# Altium schematic sheet Sheet1.SchDoc
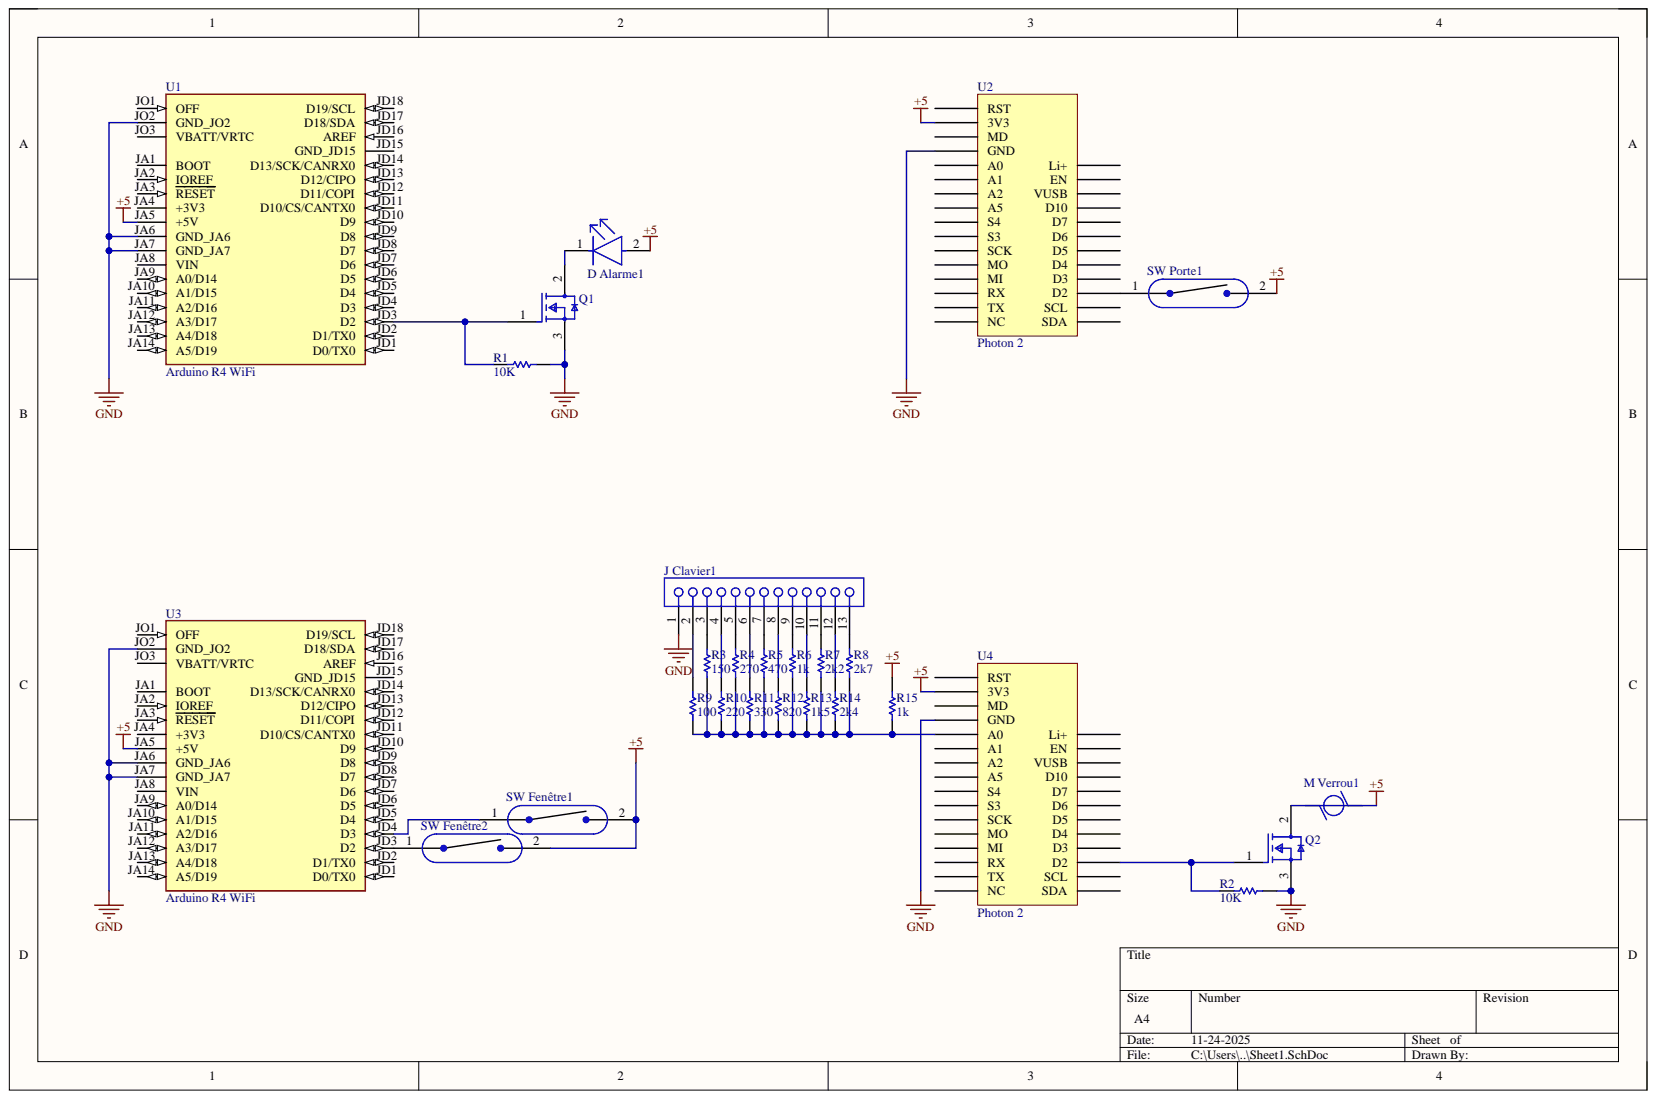
[diagram p0: Sheet1.SchDoc]

## Parts
D_Alarme1  HLMP-1301  [FP-HLMP-1301-MFG]  (value not drawn)
J_Clavier1  52808-1370  [MOLX-52808-1370]  (value not drawn)
M_Verrou1  MUMA042P1S  [FP-MUMA042P1S-MFG]  (value not drawn)
Q1  RJK6035DPP-A0#T2  [SIP450W69P254L1000H1755Q3_PRSS0003AP-A_N]  (value not drawn)
Q2  RJK6035DPP-A0#T2  [SIP450W69P254L1000H1755Q3_PRSS0003AP-A_N]  (value not drawn)
R1  Resistance: 10K  [RESC1609X55X30NL10T20]  (hidden comment: TNPW060310K0BEEN)
R2  Resistance: 10K  [RESC1609X55X30NL10T20]  (hidden comment: TNPW060310K0BEEN)
R3  CPF0201D150RE1  [RESC0603X28X15ML05T05]  (value not drawn)
R4  ERJ2RKF2700X  [RESC1005X40X25NL05T05]  (value not drawn)
R5  CRCW1210470RJNEAHP  [RESC3225X60X45NL20T20]  (value not drawn)
R6  Resistance: 1k  [RESC1005X35X25ML10T10]  (hidden comment: RT0402DRE071KL)
R7  Resistance: 2k2  [RESC0805(2012)_N]  (hidden comment: 2K2 1% 0805(2012))
R8  Resistance: 2k7  [RESC0603(1608)_M]  (hidden comment: 2K7 5% 0603(1608))
R9  RC0603JR-13100RL  [RESC1608X55X25LL10T15]  (value not drawn)
R10  CRCW1210220RJNEAHP  [RESC3225X60X45LL20T20]  (value not drawn)
R11  SG73P1JTTD3300F  [RESC1608X55X30ML20T10]  (value not drawn)
R12  CR0402-JW-821GLF  [RESC1005X40X25NL10T10]  (value not drawn)
R13  Resistance: 1k5  [RESC3216X65X50LL15T25]  (hidden comment: TNPW12061K50BEEA)
R14  Resistance: 2k4  [RESC1005X40X25ML05T10]  (hidden comment: MCSR04X2401FTL)
R15  Resistance: 1k  [RESC1005X35X25ML10T10]  (hidden comment: RT0402DRE071KL)
SW_Fenêtre1  MK15-B-2  [STAN-MK15-B-2_V]  (value not drawn)
SW_Fenêtre2  MK15-B-2  [STAN-MK15-B-2_V]  (value not drawn)
SW_Porte1  MK15-B-2  [STAN-MK15-B-2_V]  (value not drawn)
U1  Arduino R4 WiFi  MF: Arduino  [MODULE_ABX00087]
U2  Photon 2  [Photon 2-Footprint-1]
U3  Arduino R4 WiFi  MF: Arduino  [MODULE_ABX00087]
U4  Photon 2  [Photon 2-Footprint-1]

## Nets
+5: D_Alarme1.2 Q2.2 R15.2 SW_Fenêtre1.2 SW_Fenêtre2.2 SW_Porte1.2 U1.JA5(+5V) U2.1(3V3) U3.JA5(+5V) U4.1(3V3)
GND: J_Clavier1.1 Q1.3 Q2.3 R1.2 R2.2 U1.JA6(GND_JA6) U1.JA7(GND_JA7) U1.JO2(GND_JO2) U2.3(GND) U3.JA6(GND_JA6) U3.JA7(GND_JA7) U3.JO2(GND_JO2) U4.3(GND)
NetD Alarme1_1: D_Alarme1.1 Q1.2
NetJ Clavier1_2: J_Clavier1.2 R9.2
NetJ Clavier1_3: J_Clavier1.3 R3.2
NetJ Clavier1_4: J_Clavier1.4 R10.2
NetJ Clavier1_5: J_Clavier1.5 R4.2
NetJ Clavier1_6: J_Clavier1.6 R11.2
NetJ Clavier1_7: J_Clavier1.7 R5.2
NetJ Clavier1_8: J_Clavier1.8 R12.2
NetJ Clavier1_9: J_Clavier1.9 R6.2
NetJ Clavier1_10: J_Clavier1.10 R13.2
NetJ Clavier1_11: J_Clavier1.11 R7.2
NetJ Clavier1_12: J_Clavier1.12 R14.2
NetJ Clavier1_13: J_Clavier1.13 R8.2
NetQ1_1: Q1.1 R1.1 U1.JD3(D2)
NetQ2_1: Q2.1 R2.1 U4.25(D2)
NetR3_1: R3.1 R4.1 R5.1 R6.1 R7.1 R8.1 R9.1 R10.1 R11.1 R12.1 R13.1 R14.1 R15.1 U4.4(A0)
NetSW Fenêtre1_1: SW_Fenêtre1.1 U3.JD4(D3)
NetSW Fenêtre2_1: SW_Fenêtre2.1 U3.JD3(D2)
NetSW Porte1_1: SW_Porte1.1 U2.25(D2)

Not connected: U1 JA1(BOOT) JA2(IOREF) JA3(RESET) JA4(+3V3) JA8(VIN) JA9(A0/D14) JA10(A1/D15) JA11(A2/D16) JA12(A3/D17) JA13(A4/D18) JA14(A5/D19) JD1(D0/TX0) JD2(D1/TX0) JD4(D3) JD5(D4) JD6(D5) JD7(D6) JD8(D7) JD9(D8) JD10(D9) JD11(D10/CS/CANTX0) JD12(D11/COPI) JD13(D12/CIPO) JD14(D13/SCK/CANRX0) JD15(GND_JD15) JD16(AREF) JD17(D18/SDA) JD18(D19/SCL) JO1(OFF) JO3(VBATT/VRTC); U2 0(RST) 2(MD) 4(A0) 5(A1) 6(A2) 7(A5) 8(S4) 9(S3) 10(SCK) 11(MO) 12(MI) 13(RX) 14(TX) 15(NC) 16(Li+) 17(EN) 18(VUSB) 19(D10) 20(D7) 21(D6) 22(D5) 23(D4) 24(D3) 26(SCL) 27(SDA); U3 JA1(BOOT) JA2(IOREF) JA3(RESET) JA4(+3V3) JA8(VIN) JA9(A0/D14) JA10(A1/D15) JA11(A2/D16) JA12(A3/D17) JA13(A4/D18) JA14(A5/D19) JD1(D0/TX0) JD2(D1/TX0) JD5(D4) JD6(D5) JD7(D6) JD8(D7) JD9(D8) JD10(D9) JD11(D10/CS/CANTX0) JD12(D11/COPI) JD13(D12/CIPO) JD14(D13/SCK/CANRX0) JD15(GND_JD15) JD16(AREF) JD17(D18/SDA) JD18(D19/SCL) JO1(OFF) JO3(VBATT/VRTC); U4 0(RST) 2(MD) 5(A1) 6(A2) 7(A5) 8(S4) 9(S3) 10(SCK) 11(MO) 12(MI) 13(RX) 14(TX) 15(NC) 16(Li+) 17(EN) 18(VUSB) 19(D10) 20(D7) 21(D6) 22(D5) 23(D4) 24(D3) 26(SCL) 27(SDA)

## Library data of the parts
D_Alarme1 = CMP-18399-000007-1: LED RED DIFFUSED T-1 T/H; Manufacturer: Broadcom; Forward Current: 10mA; Forward Voltage: 1.9V; Led Orientation: Straight; Luminous Intensity: 3.4mcd; Max Junction Temp: +110°C; Peak Emmision Wavelength: 635nm; Reverse Voltage: 5V; Viewing Angle: 60°; Wavelength: 626nm; Automotive: No; Category: Diode; Colour: Red; Component Kind: Standard; ComponentLink2Description: Datasheet; ComponentLink2URL: https://docs.broadcom.com/docs/AV02-1555EN; Device Class L1: Optoelectronics; Device Class L2: LEDs; Device Class L3: unset; Digikey Description: LED RED DIFFUSED T-1 T/H; Lead Free: Yes; Library Name: Altium Content Vault; Manufacturer Part Number: HLMP-1301; Mouser Description: Standard LEDs - Through Hole Red Diffused 635nm 3.4mcd; Octopart: https://octopart.com/hlmp-1301-broadcom-71130345; Package: 0; RoHS: TRUE; Temperature: -40°C to +100°C; Temperature Range High: +100°C; Temperature Range Low: -40°C
J_Clavier1 = CMP-1241-00419-1: 1.0 mm Pitch Easy-On(TM) Type FFC Connector, 5.05 mm Mated Height, Vertical, Non-ZIF, SMT, 13 Circuits, -20 to 80 degC, ELV and RoHS Compliant, Tape and Reel; Manufacturer: Molex; Circuits (Loaded): 13; Current (Max per Contact): 0.5A; Durability (mating cycles max): 10; Mated Height: 5.05mm; Material - Metal: Phosphor Bronze; Material - Plating Mating: Tin-Bismuth; PCB Locator: No; PCB Retention: None; Pitch - Mating Interface: 1.00mm; Voltage (Max): 125V AC (RMS)/DC; Circuits (maximum): 13; Component Kind: Standard; ComponentLink1Description: Manufacturer URL; ComponentLink1URL: http://www.molex.com/molex/index.jsp; ComponentLink2Description: Datasheet; ComponentLink2URL: http://www.molex.com/webdocs/datasheets/pdf/en-us/0528081370_FFC_FPC_CONNECTORS.pdf; ComponentLink3Description: Package Specification; ComponentLink3URL: http://www.molex.com/pdm_docs/sd/528081370_sd.pdf; Contact Position: N/A; Entry Angle: Vertical; Library Name: Altium Content Vault; Material - Plating Termination: Tin-Bismuth; Mounting Technology: Surface Mount; Number of Rows: 1; Orientation: Vertical; PackageDescription: 13-Lead FFC Connector, Pitch 1.0 mm; PackageReference: 52808-1370; PackageVersion: Rev. B, 01/2014; Packing: Tape and Reel; PartNumber: 52808-1370; Pitch - Termination Interface: 1.00mm; Polarized to PCB: No; RoHS: TRUE; Stackable: No
M_Verrou1 = CMP-00287-09527662-1: MUMA042P1S Servo Motor; Single Phase; 200 Volt; 400 Watt; Encoder; Key Way w/CT; w/o Brake; Approvals: CE, CSA, TUV, UL; Encoder Type: Incremental; Mounting Hole Spacing: 70.0024mm; Power Rating: 400W; RPM: 3000RPM; Ambient Temperature Range High: 40°C; Case/Package: 200; Component Kind: Standard; Library Name: Altium Content Vault; Max Operating Temperature: 40°C; Min Operating Temperature: 0°C; Mount: Screw; NexarPartId: 9527662; RoHS: Compliant; Termination: Connector; Weight: 1.496855kg
Q1 Q2 = CMP-00572-106895673-1: Transistor MOSFET N-Channel 600V 6A 3-Pin TO-220FPA Box; Manufacturer Lifecycle Status: ACTIVE; Channel Type: Unidirectional; Gate to Source Voltage (Vgs): 30V; Rds On Max: 1.37Ω; Threshold Voltage: 3V; China RoHS: Compliant; Component Kind: Standard; ComponentLink1Description: Manufacturer's Website; ComponentLink1URL: http://www.renesas.com; ComponentLink2Description: Datasheet URL; ComponentLink2URL: https://octopart.com/cad-content/api/get-model-datasheet/15189/106895673; ComponentLink3Description: Manufacturer's Package Drawing; ComponentLink3URL: https://www.renesas.com/en/document/psc/package-drawing-220fpa-3pin-prss0003ap?r=575576; Continuous Drain Current (ID): 6A; Drain to Source Voltage (Vdss): 600V; Export Control Classification Number (ECCN) Code: EAR99; Height: 4.7mm; HelpURL: https://octopart.com/cad-content/api/get-model-datasheet/15189/106895673; Library Name: Altium Content Vault; Lifecycle Status: Production; Max Operating Temperature: 150°C; Mount: Through Hole; Number of Pins: 3; RoHS: Compliant
R1 R2 = CMP-2000-03692-1: Manufacturer: Vishay Dale; Component Kind: Standard; Library Name: Altium Content Vault; Part Number: TNPW060310K0BEEN
R3 = CMP-2004-03864-1: Manufacturer: TE Connectivity / AMP; Resistance: 150; Component Kind: Standard; Library Name: Altium Content Vault; Part Number: CPF0201D150RE1
R4 = CMP-1011-00444-2: Manufacturer: Panasonic; Resistance: 270; Component Kind: Standard; Library Name: Altium Content Vault; Part Number: ERJ2RKF2700X
R5 = CMP-2005-00256-1: Manufacturer: Vishay Dale; Resistance: 470; Component Kind: Standard; Library Name: Altium Content Vault; Part Number: CRCW1210470RJNEAHP
R6 R15 = CMP-2002-02598-1: Manufacturer: Yageo; Component Kind: Standard; Library Name: Altium Content Vault; Part Number: RT0402DRE071KL
R7 = CMP-1013-00546-1: 2K2 0.125W 1% 0805 (2012 Metric)  SMD; Case-EIA: 0805; Case-Metric: 2012; Value: 2200.00 Ohm; Component Kind: Standard; Library Name: Altium Content Vault; Power: 0.125 W; Technology: SMT; Tolerance: 1 %
R8 = CMP-1012-00084-1: 2K7 0.1W 5% 0603 (1608 Metric)  SMD; Case-EIA: 0603; Case-Metric: 1608; Value: 2700.00 Ohm; Component Kind: Standard; Library Name: Altium Content Vault; Power: 0.1 W; Technology: SMT; Tolerance: 5 %
R9 = CMP-2000-04313-1: Manufacturer: Yageo; Resistance: 100; Component Kind: Standard; Library Name: Altium Content Vault; Part Number: RC0603JR-13100RL
R10 = CMP-2005-00232-1: Manufacturer: Vishay Dale; Resistance: 220; Component Kind: Standard; Library Name: Altium Content Vault; Part Number: CRCW1210220RJNEAHP
R11 = CMP-2000-01791-1: Manufacturer: KOA Speer; Resistance: 330; Component Kind: Standard; Library Name: Altium Content Vault; Part Number: SG73P1JTTD3300F
R12 = CMP-2002-05669-1: Manufacturer: Bourns; Resistance: 820; Component Kind: Standard; Library Name: Altium Content Vault; Part Number: CR0402-JW-821GLF
R13 = CMP-2003-04646-1: Manufacturer: Vishay Dale; Component Kind: Standard; Library Name: Altium Content Vault; Part Number: TNPW12061K50BEEA
R14 = CMP-2002-06929-1: Manufacturer: Multicomp; Component Kind: Standard; Library Name: Altium Content Vault; Part Number: MCSR04X2401FTL
SW_Fenêtre1 SW_Fenêtre2 SW_Porte1 = CMP-2000-06712-1: Reed Sensor, SPST-NO, 10 W, 180 V, -40 to 130 degC, 2-Pin SMD, RoHS, Tape and Reel; Manufacturer: Standex Meder; Component Kind: Standard; Datasheet URL: https://standexelectronics.com/wp-content/uploads/datasheet_reed_sensor_MK15.pdf; Datasheet Version: Rev. 01, 01/2015; Library Name: Altium Content Vault; Manufacturer URL: https://standexelectronics.com; Mounting Technology: SurfaceMount; Package Description: 2-Pin Surface Mount Device, Body 16 x 2.5 mm; Package Reference: MK15-B-2; Package URL: http://www.farnell.com/datasheets/34737.pdf
U1 U3 = CMP-052-000000-1: Arduino Uno Rev4 Wifi Rohs Compliant: Yes; MP: ABX00087; Check_prices: https://www.snapeda.com/parts/ABX00087/Arduino/view-part/?ref=eda; Component Kind: Standard; Component Type: Standard; Design Item ID: ABX00087; Package: None; Pin Count: 35; SnapEDA_Link: https://www.snapeda.com/parts/ABX00087/Arduino/view-part/?ref=snap; Source: ABX00087.SchLib; Library Name: Alary Van Eeckhout
U2 U4 = CMP-031-00007-4: Wifi and BLE; Component Kind: Standard; Library Name: Alary Van Eeckhout
